# Altium schematic sheet Sheet1.SchDoc
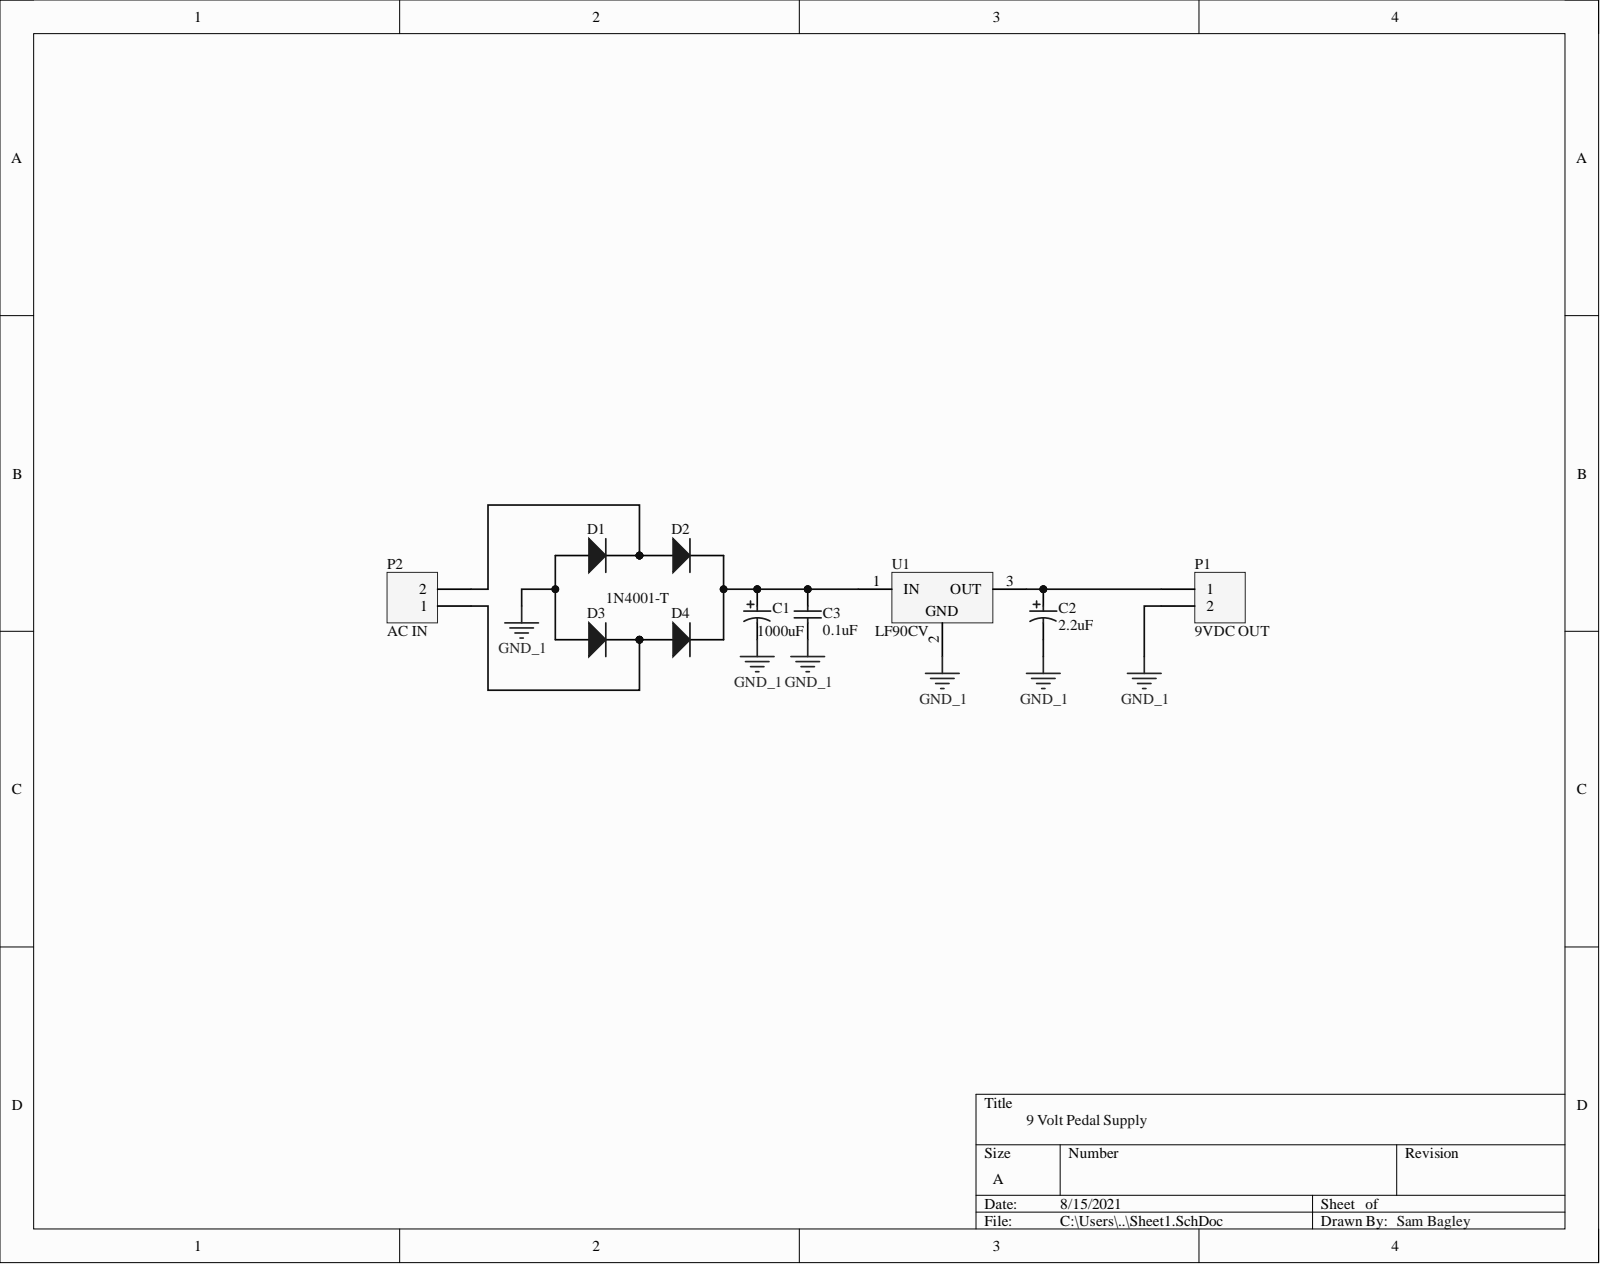
[diagram p0: Sheet1.SchDoc]

## Parts
C1  1000uF  [PNSC-EEUFM1H221-2]
C2  2.2uF  [CAPPR55-200-1100X500X1200]
C3  0.1uF  [VISH-K104K15X7RF5TL2]
D1  1N4001-T  [DIOD-DO-41-P-2_V]
D2  1N4001-T  [DIOD-DO-41-P-2_V]
D3  1N4001-T  [DIOD-DO-41-P-2_V]
D4  1N4001-T  [DIOD-DO-41-P-2_V]
P1  9VDC OUT  [MHDR1X2]
P2  AC IN  [MHDR1X2]
U1  LF90CV  [TO220]

## Nets
GND_1: C1.2 C2.2 C3.1 D1.1 D3.1 P1.2 U1.2
NetC1_1: C1.1 C3.2 D2.2 D4.2 U1.1
NetC2_1: C2.1 P1.1 U1.3
NetD1_2: D1.2 D2.1 P2.2
NetD3_2: D3.2 D4.1 P2.1

## Library data of the parts
C1 = CMP-2000-05313-1: Aluminum Electrolytic Capacitor, 1000 uF, +/- 20%, 16 V, -40 to 105 degC, 2-Pin THD, RoHS, Tape and Reel; Manufacturer: Panasonic; Capacitance: 1000µF; Impedance: 0.028O; Lifetime@Temperature: 8000Hrs@105degC; Rated Voltage: 16V; Ripple Current: 1790mA; Type: Radial; Case Size/Dimension: Ø10x16mm; Component Kind: Standard; Datasheet URL: http://www.produktinfo.conrad.com/datenblaetter/425000-449999/446746-da-01-en-ALU_KONDENSATOR_FR_R_1000_UF_16V.pdf; Datasheet Version: Nov-11; Library Name: Altium Content Vault; Manufacturer URL: http://www.panasonic.com/; Operating Temp Range: -40to+105degC; Operating Temp-Max: 105degC; Series: FR
C2 = CMP-1665-00040-1: Aluminum Electrolytic Capacitor, 2.2 uF, +/- 20%, 50 V, -40 to 85 degC, 2-Pin THD, RoHS, Bulk; Case\Package: QFN; Interface: I2C; Load Capacitance: 400 pF; Max Supply Voltage: 3.6 V; Min Supply Voltage: 1.71 V; Nominal Supply Voltage: 2.5 V; Component Kind: Standard; ComponentLink1Description: Manufacturer URL; ComponentLink1URL: http://www.panasonic.com/; ComponentLink2Description: Datasheet; ComponentLink2URL: http://industrial.panasonic.com/cdbs/www-data/pdf/RDF0000/DMF0000COL68.pdf; DatasheetVersion: Mar-16; Height: 0.65 mm; Length: 3 mm; Library Name: Altium Content Vault; Max Operating Temperature: 85 degC; Min Operating Temperature: -40 degC; Mount: Surface Mount; Package Quantity: 1; PackageDescription: 2-Pin Radial Lead, Dia 5 mm, Body Length 11 mm; PackageReference: CAPPR55-200-1100X500X1200; Packaging: Cut Tape; Pins: 20; Radiation Hardening: No; REACH SVHC: No SVHC; RoHSCompliant: true; Weight: 0.000617 oz; Width: 3 mm
C3 = CMP-2000-06508-1: Ceramic Capacitor, 100 nF, +/- 10%, 50 V, -55 to 125 degC, 2-Pin THD, RoHS, Tape and Reel; Manufacturer: Vishay; Capacitance: 0.1µF; Catalog Drawings: Mono-KapSide2-15_5.58Mono-KapSide1_15; Online Catalog: Mono-Kap™Series; Other Names: BC1084CT; Temperature Coefficient: X7R; Voltage - Rated: 50V; Applications: GeneralPurpose; Category: Capacitors; Component Kind: Standard; Datasheet URL: http://www.vishay.com/docs/45171/kseries.pdf; Datasheet Version: 08/2013; Family: CeramicCapacitors; Features: -; Height - Seated (Max): 0.220"(5.58mm); Lead Spacing: 0.098"(2.50mm); Lead Style: Straight; Library Name: Altium Content Vault; Manufacturer URL: http://www.vishay.com/; Mounting Technology: ThroughHole; Mounting Type: ThroughHole; Operating Temperature: -55°C~125°C; Package / Case: Radial; Package Description: 2-Pin Through Hole Device, Body 4 x 2.6 mm; Package Reference: K104K15X7RF5TL2; Packaging: CutTape(CT); Ratings: -; Series: Mono-Kap™K; Size / Dimension: 0.157"Lx0.098"W(4.00mmx2.50mm); Standard Package: 1; Thickness (Max): -; Tolerance: ±10%
D1-D4 = CMP-0639-00006-2: 1.0 A Rectifier, 15 pF, 50 V, -65 to 150 degC, 2-Pin DO-41 Plastic, RoHS, Tape and Reel; Manufacturer: Diodes Inc; CT (pF): 15; Max. Average Rectified Current IO (A): 1; Max. IFSM (A): 30; Max. IR (uA): 5; VRRM (V): 50; Component Kind: Standard; ComponentLink1Description: Manufacturer URL; ComponentLink1URL: http://diodes.com/; ComponentLink2Description: Datasheet; ComponentLink2URL: http://diodes.com/datasheets/ds28002.pdf; DatasheetVersion: Rev. 8-2; Library Name: Altium Content Vault; Mounting Technology: Through Hole; Operating Temperature Range (degC): -65 to 150; PackageDescription: 2-Pin Axial Lead, Body 4.635 x 2.36 mm, Lead Spread 25.4 mm; PackageReference: DO-41-P-2; Packing: Tape and Reel; PartNumber: 1N4001-T; RoHS: TRUE; VF (V): 1
P1 P2 = MHDR1X2: Header, 2-Pin; Component Kind: Standard; LatestRevisionDate: 17-Jul-2002; LatestRevisionNote: Re-released for DXP Platform.; Library Name: Miscellaneous Connectors.IntLib; Signal Integrity: Connector; Publisher: Altium Limited
U1 = CMP-0244-00445-1: 500mA, Very Low Drop (0.45V) Voltage Regulator, 9V, 3-Pin TO-220; Component Kind: Standard; ComponentLink1Description: Manufacturer URL; ComponentLink1URL: http://www.st.com; ComponentLink2Description: Datasheet; ComponentLink2URL: http://www.st.com/internet/com/TECHNICAL_RESOURCES/TECHNICAL_LITERATURE/DATASHEET/CD00000546.pdf; ComponentLink3Description: Package Specification; ComponentLink3URL: http://www.st.com/internet/com/TECHNICAL_RESOURCES/TECHNICAL_LITERATURE/PACKAGE_INFORMATION/CD00004649.pdf; DatasheetVersion: 1/11/2010; Library Name: Altium Content Vault; PackageDescription: TO, Thru-Hole, Vertical, Heatsink Mounted; 3 In-Line Leads; Pitch 2.54 mm; PackageReference: TO220; PackageVersion: ver3.0
